# Altium schematic sheet Power_Connector_Orb_Side_3-0.SchDoc(Power_Connector_Orb_Side_3-0)
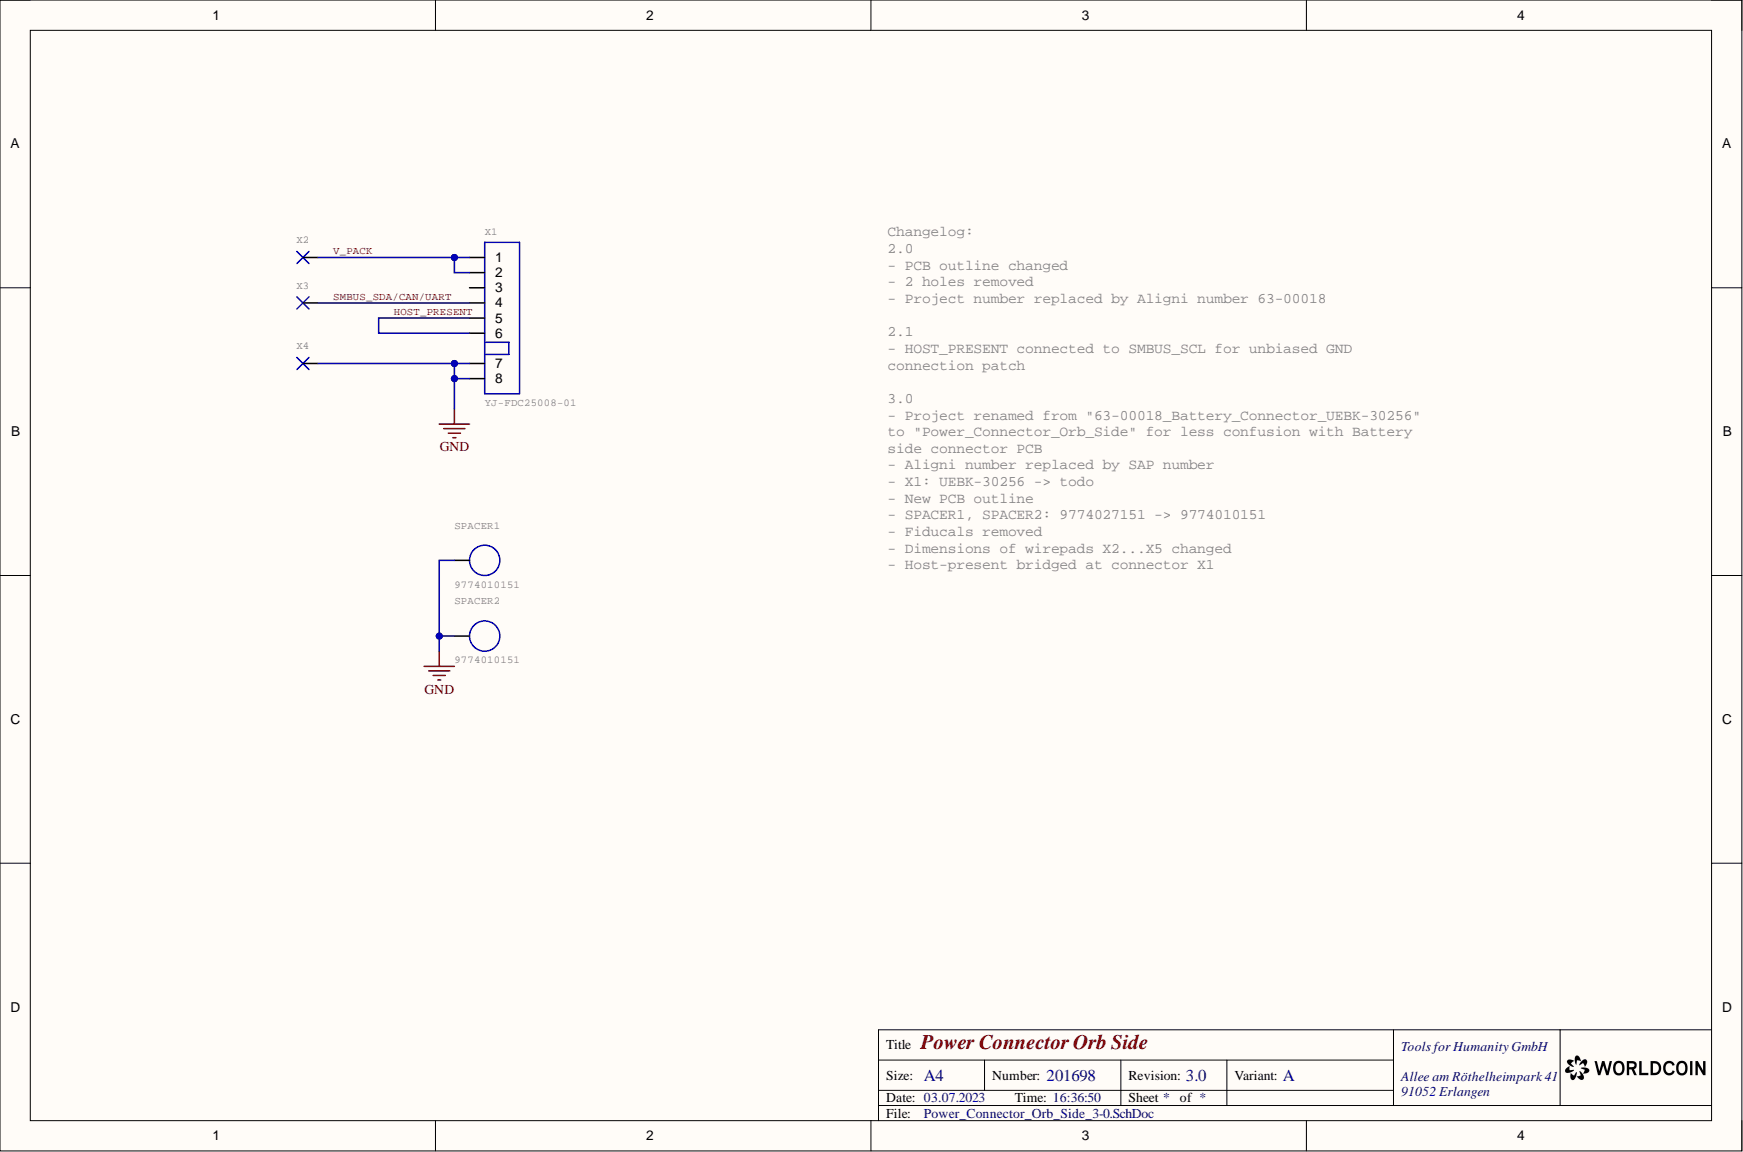
[diagram p0: Power_Connector_Orb_Side_3-0.SchDoc]

## Parts
SPACER1  9774010151  [WA-SMSI_9774010151_WUERTH]
SPACER2  9774010151  [WA-SMSI_9774010151_WUERTH]
X1  YJ-FDC25008-01  [YJ-FDC25008-01_DONGGUAN]
X2  PAD_RECT_VIAS_2X12MM  [PAD_RECT_VIAS_2X12MM]  (value not drawn)  (no BOM)
X3  PAD_RECT_VIAS_2X12MM  [PAD_RECT_VIAS_2X12MM]  (value not drawn)  (no BOM)
X4  PAD_RECT_VIAS_2X12MM  [PAD_RECT_VIAS_2X12MM]  (value not drawn)  (no BOM)

## Nets
GND: SPACER1.1 SPACER2.1 X1.7 X1.8 X4.1
HOST_PRESENT: X1.5 X1.6
SMBUS_SDA/CAN/UART: X1.4 X3.1
V_PACK: X1.1 X1.2 X2.1

Not connected: X1 3

## Library data of the parts
SPACER1 SPACER2 = CON-00168-7: 9774010151, WA-SMSI SMT Steel Spacer, M2.5 Thread Internal, L = 1.0 mm; Aligni Part Number 1: 61-00372; Manufacturer 1: Wuerth; Manufacturer Part Number 1: 9774010151R; CURRENT: 0A; MAX_OP_TEMP: 150°C; MIN_OP_TEMP: -55°C; SAP Material Number 1: 200340; CHANGED: 2022-01-11; Component Kind: Standard; CREATED: 2021-09-07; ROHS: yes; STATUS: active; Library Name: Worldcoin
X1 = CON-00238-5: Battery connector, 2.5 mm pitch, max. 10 A, 8 pins; Aligni Part Number 1: 62-00067; Manufacturer 1: Dongguan Yangjie Electronic Technology Co.,Ltd; CURRENT: 10A; MAX_OP_TEMP: 105°C; MIN_OP_TEMP: -40°C; SAP Material Number 1: 201768; CHANGED: 2023-07-03; Component Kind: Standard; CREATED: 2023-05-15; Manufacturer Part Number 1: YJ-FDC25008-01; ROHS: yes; STATUS: active; Library Name: Worldcoin
X2-X4 = CON-00239-2: SMD pad 2.0 mm x 12.0 mm with vias for increasing mechanical strength; CURRENT: 0A; MAX_OP_TEMP: -100°C; MIN_OP_TEMP: 100°C; CHANGED: 2023-06-27; CREATED: 2023-05-15; ROHS: NA; STATUS: NA; Library Name: Worldcoin
